# Altium schematic sheet Microcontroller.SchDoc(Microcontroller)
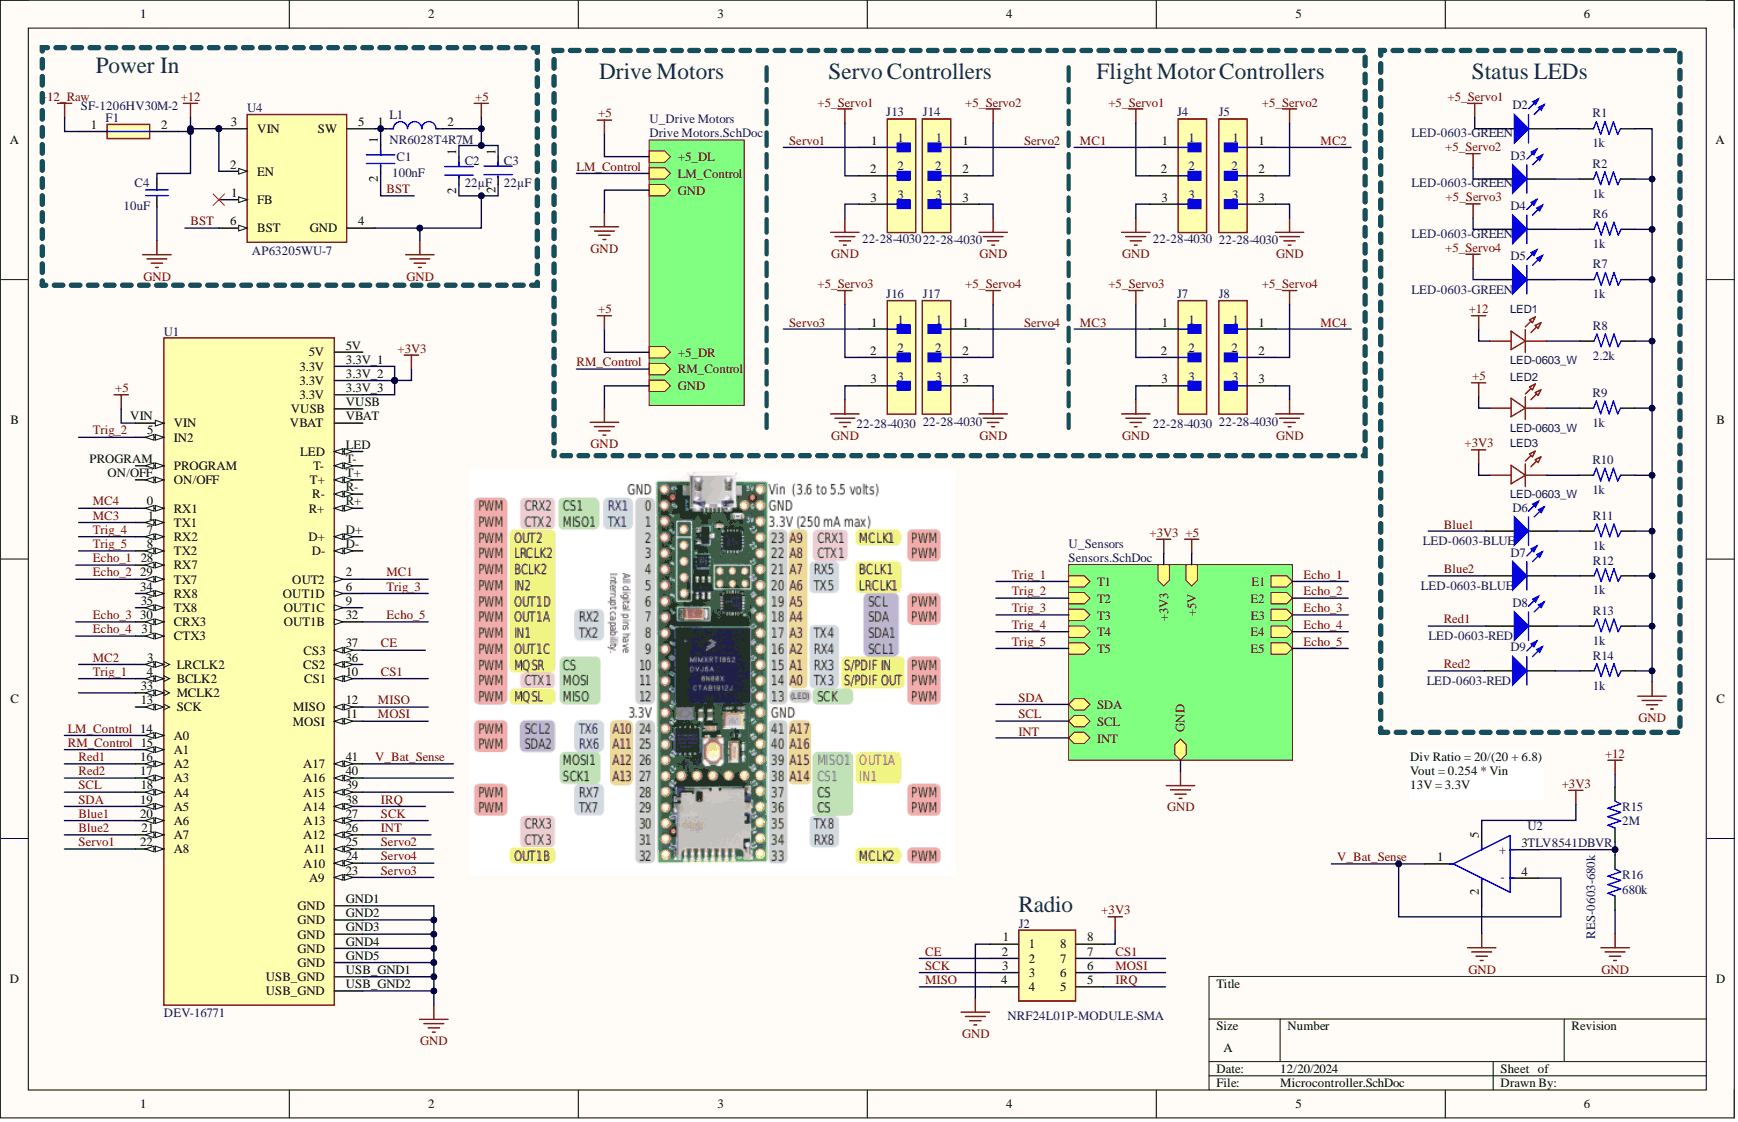
[diagram p0: Microcontroller.SchDoc]

## Parts
C1  Capacitance: 100nF
C2  Capacitance: 22µF
C3  Capacitance: 22µF
C4  value 10uF
D2  LED-0603-GREEN
D3  LED-0603-GREEN
D4  LED-0603-GREEN
D5  LED-0603-GREEN
D6  LED-0603-BLUE
D7  LED-0603-BLUE
D8  LED-0603-RED
D9  LED-0603-RED
F1  SF-1206HV30M-2
J2  NRF24L01P-MODULE-SMA
J4  22-28-4030
J5  22-28-4030
J7  22-28-4030
J8  22-28-4030
J13  22-28-4030
J14  22-28-4030
J16  22-28-4030
J17  22-28-4030
L1  NR6028T4R7M
LED1  LED-0603_W
LED2  LED-0603_W
LED3  LED-0603_W
R1  value 1k  (hidden comment: RES-0603-1k)
R2  value 1k  (hidden comment: RES-0603-1k)
R6  value 1k  (hidden comment: RES-0603-1k)
R7  value 1k  (hidden comment: RES-0603-1k)
R8  value 2.2k
R9  value 1k  (hidden comment: RES-0603-1k)
R10  value 1k  (hidden comment: RES-0603-1k)
R11  value 1k  (hidden comment: RES-0603-1k)
R12  value 1k  (hidden comment: RES-0603-1k)
R13  value 1k  (hidden comment: RES-0603-1k)
R14  value 1k  (hidden comment: RES-0603-1k)
R15  value 2M
R16  RES-0603-680k  value 680k
U1  DEV-16771
U2  TLV8541DBVR
U4  AP63205WU-7

## Nets
+3V3: J2.8 LED3.1 U1.3.3V_1(3.3V) U1.3.3V_2(3.3V) U1.3.3V_3(3.3V) U2.5
+5: C2.1 C3.1 L1.2 LED2.1 U1.VIN
+5_Servo1: D2.1 J4.2 J13.2
+5_Servo2: D3.1 J5.2 J14.2
+5_Servo3: D4.1 J7.2 J16.2
+5_Servo4: D5.1 J8.2 J17.2
+12: C4.1 F1.2 LED1.1 R15.2 U4.2(EN) U4.3(VIN)
+12_Raw: F1.1
GND: C2.2 C3.2 C4.2 J2.1 J4.3 J5.3 J7.3 J8.3 J13.3 J14.3 J16.3 J17.3 R1.2 R2.2 R6.2 R7.2 R8.2 R9.2 R10.2 R11.2 R12.2 R13.2 R14.2 R16.1 U1.GND1(GND) U1.GND2(GND) U1.GND3(GND) U1.GND4(GND) U1.GND5(GND) U1.USB_GND1(USB_GND) U1.USB_GND2(USB_GND) U2.2 U4.4(GND)
Blue1: D6.1 U1.20(A6)
Blue2: D7.1 U1.21(A7)
BST: C1.2 U4.6(BST)
CE: J2.2 U1.37(CS3)
CS1: J2.7 U1.10(CS1)
Echo_1: U1.28(RX7)
Echo_2: U1.29(TX7)
Echo_3: U1.30(CRX3)
Echo_4: U1.31(CTX3)
Echo_5: U1.32(OUT1B)
INT: U1.26(A12)
IRQ: J2.5 U1.38(A14)
LM_Control: U1.14(A0)
MC1: J4.1 U1.2(OUT2)
MC2: J5.1 U1.3(LRCLK2)
MC3: J7.1 U1.1(TX1)
MC4: J8.1 U1.0(RX1)
MISO: J2.4 U1.12(MISO)
MOSI: J2.6 U1.11(MOSI)
Red1: D8.1 U1.16(A2)
Red2: D9.1 U1.17(A3)
RM_Control: U1.15(A1)
SCK: J2.3 U1.27(A13)
SCL: U1.18(A4)
SDA: U1.19(A5)
Servo1: J13.1 U1.22(A8)
Servo2: J14.1 U1.25(A11)
Servo3: J16.1 U1.23(A9)
Servo4: J17.1 U1.24(A10)
Trig_1: U1.4(BCLK2)
Trig_2: U1.5(IN2)
Trig_3: U1.6(OUT1D)
Trig_4: U1.7(RX2)
Trig_5: U1.8(TX2)
V_Bat_Sense: U1.41(A17) U2.1 U2.4(-)
NetC1_1: C1.1 L1.1 U4.5(SW)
NetD2_2: D2.2 R1.1
NetD3_2: D3.2 R2.1
NetD4_2: D4.2 R6.1
NetD5_2: D5.2 R7.1
NetD6_2: D6.2 R11.1
NetD7_2: D7.2 R12.1
NetD8_2: D8.2 R13.1
NetD9_2: D9.2 R14.1
NetLED1_2: LED1.2 R8.1
NetLED2_2: LED2.2 R9.1
NetLED3_2: LED3.2 R10.1
NetR15_1: R15.1 R16.2 U2.3(+)
NetU1_5V: U1.5V
NetU1_9: U1.9(OUT1C)
NetU1_13: U1.13(SCK)
NetU1_33: U1.33(MCLK2)
NetU1_34: U1.34(RX8)
NetU1_35: U1.35(TX8)
NetU1_36: U1.36(CS2)
NetU1_39: U1.39(A15)
NetU1_40: U1.40(A16)
NetU1_D+: U1.D+
NetU1_D-: U1.D-
NetU1_LED: U1.LED
NetU1_ON/OFF: U1.ON/OFF
NetU1_PROGRAM: U1.PROGRAM
NetU1_R+: U1.R+
NetU1_R-: U1.R-
NetU1_T+: U1.T+
NetU1_T-: U1.T-
NetU1_VBAT: U1.VBAT
NetU1_VUSB: U1.VUSB
NetU4_1: U4.1(FB)

## Library data of the parts
C1 = CMP-292-000024-1: General Purpose Ceramic Capacitor, 0603, 100nF, 10%, X7R, 15%, 50V; Manufacturer: KEMET; Manufacturer Part Number: C0603C104K5RACTU; Dissipation Factor: 2.5%; Case Code (Metric): 1608; Component Kind: Standard; Component Type: Standard; Contact Plating: Tin; Depth: 0.81mm; Design Item ID: CMP-1035-02592-4; Dielectric: X7R; Dielectric Material: Ceramic; Height: 0.9mm; Lead Free: Lead Free; Length: 1.6mm; Max Operating Temperature: 125°C; Min Operating Temperature: -55°C; Mount: Surface Mount; Number of Pins: 2; Package Quantity: 1; Packaging: Tape and Reel; Pin Count: 2; Radiation Hardening: No; REACH SVHC: No SVHC; Source: PV Buck v2.SCHLIB; Termination: SMD/SMT; Thickness: 0.035inch; Tolerance: 10%; Voltage: 50V; Width: 0.81mm; Library Name: Formula Electric at Berkeley
C2 C3 = CMP-292-000025-1: Multilayer Ceramic Capacitors 22µF ±20% 35V X5R SMD 0805; Manufacturer: TDK; Manufacturer Part Number: C2012X5R1V226M125AC; Case Code (Metric): 2012; Component Kind: Standard; Component Type: Standard; Design Item ID: CMP-2000-06038-3; Dielectric: X5R; Dielectric Material: Ceramic; Height: 1.25mm; Lead Free: Lead Free; Length: 0.079inch; Max Operating Temperature: 85°C; Min Operating Temperature: -55°C; Mount: Surface Mount; Package Quantity: 2000; Packaging: Tape and Reel; Pin Count: 2; REACH SVHC: No SVHC; RoHS Compliant: Yes; Series: C; Source: PV Buck v2.SCHLIB; Termination: SMD/SMT; Thickness: 0.057inch; Tolerance: 20%; Weight: 0.000194oz; Width: 0.049inch; Library Name: Formula Electric at Berkeley
C4 = CMP-001-00003-3: 0603 Footprint Capacitor, 10uF; MP: CAP-0603-10u-25V; Availability: Unavailable; Component Kind: Standard; Design Item ID: CAP-0603-10u-25V; Digi-Key Part Number: 1276-1869-1-ND; JLCPCB Part Number: CL10A106MA8NRNC; Package: None; Price: None; Source: CAP0603.SchLib; Library Name: Formula Electric at Berkeley
D2-D5 = CMP-004-00001-4: Green 0603 SMD LED; Manufacturer Product Number: LTST-C190KGKT; Component Kind: Standard; Component Type: Standard; Design Item ID: LED-0603; Digi-Key Part Number: 160-1435-1-ND; JLCPCB Part Number: C125094; JLCPCB Part Type: Extended; Mouser Part Number: 859-LTST-C190KGKT; Pin Count: 2; Source: LED0603.SchLib; Library Name: Formula Electric at Berkeley
D6 D7 = CMP-004-00002-3: Blue 0603 SMD LED; Manufacturer Product Number: LTST-C191TBKT; Component Kind: Standard; Component Type: Standard; Design Item ID: LED-0603; Digi-Key Part Number: 160-1647-1-ND; JLCPCB Part Number: C99290; JLCPCB Part Type: Extended; Mouser Part Number: 859-LTST-C191TBKT; Pin Count: 2; Source: LED0603.SchLib; Library Name: Formula Electric at Berkeley
D8 D9 = CMP-004-00000-4: Red 0603 SMD LED; Manufacturer Product Number: LTST-C190KRKT; Component Kind: Standard; Component Type: Standard; Design Item ID: LED-0603; Digi-Key Part Number: 160-1436-1-ND; JLCPCB Part Number: C125099; JLCPCB Part Type: Extended; Mouser Part Number: 859-LTST-C190KRKT; Pin Count: 2; Source: LED0603.SchLib; Library Name: Formula Electric at Berkeley
F1 = CMP-265-000002-1: Surface Mount Fuses 30A Single blow fuse 1206 SinglFuse; Manufacturer_Name: Bourns; Arrow Part Number: SF-1206HV30M-2; Arrow Price/Stock: https://www.arrow.com/en/products/sf-1206hv30m-2/bourns?region=nac; Component Kind: Standard; Datasheet Link: https://componentsearchengine.com/Datasheets/1/SF-1206HV30M-2.pdf; Design Item ID: SF-1206HV30M-2; Height: 1.5mm; Manufacturer_Part_Number: SF-1206HV30M-2; Mouser Part Number: 652-SF-1206HV30M-2; Mouser Price/Stock: https://www.mouser.co.uk/ProductDetail/Bourns/SF-1206HV30M-2?qs=gTYE2QTfZfQ0x9wKqSFQQQ%3D%3D; Source: SamacSys.SchLib; Library Name: Formula Electric at Berkeley
J2 = NRF24L01P-MODULE-SMA: Connector; Manufacturer_Name: Nordic Semiconductor; Arrow Part Number: NRF24L01P-MODULE-SMA; Arrow Price/Stock: https://www.arrow.com/en/products/nrf24l01p-module-sma/nordic-semiconductor?region=nac; Component Kind: Standard; Datasheet Link: https://infocenter.nordicsemi.com/pdf/nRF24L01P_PS_v1.0.pdf; Height: 4mm; Manufacturer_Part_Number: NRF24L01P-MODULE-SMA; Mouser Part Number: 949-NRF24L01P-MODSMA; Mouser Price/Stock: https://www.mouser.co.uk/ProductDetail/Nordic-Semiconductor/nRF24L01P-MODULE-SMA?qs=yd0qOhnSiDftbZo%2FB8rkYg%3D%3D
J4 J5 J7 J8 J13 J14 J16 J17 = CMP-04776-000098-1: CONN HEADER VERT 3POS 2.54MM; Manufacturer: Molex; Conn Gender: Male; Conn Orientation: Vertical; Current Rating: 4A; Number Of Contacts: 3; Voltage Rating: 500V; Automotive: No; Category: Conn; Component Kind: Standard; ComponentLink2Description: Datasheet; ComponentLink2URL: https://www.molex.com/webdocs/datasheets/pdf/en-us/0022284030_PCB_HEADERS.pdf; Contact Material: Gold,Nickel; Device Class L1: Connectors; Device Class L2: Headers and Wire Housings; Device Class L3: unset; Digikey Description: CONN HEADER VERT 3POS 2.54MM; Footprint Url: https://www.molex.com/pdm_docs/sd/022284030_sd.pdf; Height: 8.88mm; Lead Free: Yes; Library Name: Altium Content Vault; Manufacturer Part Number: 22-28-4030; Mouser Description: Headers & Wire Housings 3P VERT HEADER Sn; Number Of Rows: 1; Octopart: https://octopart.com/0022284030-molex-10476094; Package: 22-28-4030; Pitch: 2.54mm; RoHS: TRUE; Temperature: -40°C to +80°C; Temperature Range High: +80°C; Temperature Range Low: -40°C
L1 = CMP-14484-000037-2: SMD Power Inductors 4.7uH ±20% 2.7A 40.3mΩ; Core Material: Ferrite; Current Rating: 3A; DC Resistance (DCR): 40.3mR; Self Resonant Frequency: 39MHz; Shielding: Shielded; Test Frequency: 100kHz; Case/Package: 2424; Component Kind: Standard; Depth: 6.2mm; Halogen Free: Halogen Free; Height: 2.8mm; Height - Seated (Max): 0.11inch; Inductance: 4.7uH; Inductance Tolerance: 20%; Lead Free: Lead Free; Length: 0.236inch; Library Name: Altium Content Vault; Max DC Current: 3A; Max Operating Temperature: 120°C; Min Operating Temperature: -25°C; Mount: Surface Mount; Number of Pins: 2; Package Quantity: 2000; Packaging: Tape and Reel; Radiation Hardening: No; Resistor Type: Wirewound; RMS Current (Irms): 3A; RoHS Compliant: Yes; Saturation Current: 2.7A; Series: NR; Termination: SMD/SMT; Tolerance: 20%; Width: 0.236inch
LED1-LED3 = CMP-284-000000-3: White 0603 LED Indication - Discrete ROHS; BOM_Manufacturer: KENTO; BOM_Manufacturer Part: LED White; Manufacturer: Hubei KENTO Elec; Manufacturer Part Number: KT-0603W; BOM_Supplier: LCSC; BOM_Supplier Part: C2290; Component Kind: Standard; Datasheet URL: https://www.lcsc.com/datasheet/lcsc_datasheet_2305091500_Hubei-KENTO-Elec-KT-0603W_C2290.pdf; Design Item ID: LED-0603_W; JLCPCB Part Number: C2290; link: https://atta.szlcsc.com//upload/public/pdf/source/20160507/1462613613821.pdf; Source: All_JLC_components.SCHLIB; spicePre: L; spiceSymbolName: LED-0603_W; Library Name: Formula Electric at Berkeley
R1 R2 R6 R7 R9-R14 = CMP-009-00012-2: 0603 1kOhm Resistor; Manufacturer Product Number: RC1608F102CS; Tolerance: 1%; Voltage Rating: 50V; Component Kind: Standard; Digi-Key Part Number: 1276-3484-1-ND; JLCPCB Part Number: C21190; Source: RES0603.SchLib; Library Name: Formula Electric at Berkeley
R8 = CMP-397-000182-1: 0603 2.2kOhm Resistor; Manufacturer Product Number: RC0603FR-072K2L; Power: 1/10; Tolerance: 1%; Component Kind: Standard; Digi-Key Part Number: 311-2.20KHRCT-ND; Digi-Key_Purchase_URL: https://www.digikey.com/en/products/detail/yageo/RC0603FR-072K2L/727016; JLCPCB Part Type: Basic/Extended; Package: 0603; Source: RES0603.SchLib; Library Name: Formula Electric at Berkeley
R15 = CMP-009-00026-2: 0603 1 Ohm Resistor; Manufacturer Product Number: RC0603FR-071RP; Tolerance: 1%; Case/Package: 0603; Component Kind: Standard; Digi-Key Part Number: YAG1295CT-ND; JLCPCB Part Number: C22936; Mouser Part Number: 603-RC0603FR-071RP; Library Name: Formula Electric at Berkeley
R16 = CMP-009-00031-2: 680kOhm 0603 Resistor; Manufacturer Product Number: RC0603FR-07680KL; MP: 3-2176326-0; Availability: Unavailable; Component Kind: Standard; Design Item ID: 3-2176326-0; Digi-Key Part Number: 311-680KHRCT-ND; JLCPCB Part Number: C25822; Mouser Part Number: 603-RC0603FR-07680KL; Package: None; Price: None; Source: RES0603.SchLib; Library Name: Formula Electric at Berkeley
U1 = CMP-661-000000-1: RT1062 Teensy 4.1 series ARM® Cortex®-M7 MPU Embedded Evaluation Board; MF: SparkFun Electronics; Check_prices: https://www.snapeda.com/parts/DEV-16771/SparkFun+Electronics/view-part/?ref=eda; Component Kind: Standard; Component Type: Standard; Design Item ID: DEV-16771; MP: DEV-16771; Package: None; Pin Count: 65; SnapEDA_Link: https://www.snapeda.com/parts/DEV-16771/SparkFun+Electronics/view-part/?ref=snap; Source: DEV-16771.SchLib; Library Name: Formula Electric at Berkeley
U2 = CMP-399-000028-1: Operational Amplifier, 1 Func, 3100uV Offset-Max, PDSO5, opamp; Channels: 1; Common-mode Reject Ratio-Nom: 80dB; Input Offset Voltage-Max: 3.1mV; Nominal Supply Voltage: 1.8V; Package Body Material: Plastic; Slew Rate: 3500V/µs; Supply Voltage Limit-Max: 4V; Unity Gain BW-Nom: 8kHz; Amplifier Type: OPERATIONAL AMPLIFIER; Case/Package: LSSOP; Component Kind: Standard; Height - Seated (Max): 1.45mm; JESD-30 Code: R-PDSO-G5; JESD-609 Code: e4; Length: 2.9mm; Max Operating Temperature: 125°C; Min Operating Temperature: -40°C; Moisture Sensitivity Level: 1; Package Shape: Rectangular; Package Style: SMALL OUTLINE, LOW PROFILE, SHRINK PITCHMeter; Peak Reflow Temperature (Cel): 260°C; Pins: 5; Reach SVHC Compliant: Yes; RoHS Compliant: Yes; Surface Mount: Yes; Temperature Grade: AUTOMOTIVE; Terminal Finish: Nickel; Terminal Form: Gull Wing; Terminal Pitch: 0.95mm; Terminal Position: DUAL; Width: 1.6mm; Library Name: Formula Electric at Berkeley
U4 = CMP-12757-000001-1: IC REG BUCK 5V 2A TSOT26; Manufacturer: Diodes Inc.; Max Duty Cycle: 100%; Max Junction Temp: +160°C; Max Supply Voltage: 32V; Min Supply Voltage: 3.8V; Nominal Supply Current: 22uA; Number Of Outputs: 1; Output Type: Fixed; Standoff Height: 0.01mm; Switching Frequency: 1100kHz; Ambient Temperature Range High: +85°C; Ambient Temperature Range Low: -40°C; Automotive: No; Category: IC; Component Kind: Standard; ComponentLink2Description: Datasheet; ComponentLink2URL: https://www.diodes.com/assets/Datasheets/AP63200-AP63201-AP63203-AP63205.pdf; Device Class L1: Integrated Circuits (ICs); Device Class L2: Power Management ICs; Device Class L3: Voltage Regulators - Switching; Digikey Description: IC REG BUCK 5V 2A TSOT26; Footprint Url: https://www.diodes.com/assets/Package-Files/TSOT26.pdf; Height: 1mm; Lead Free: Yes; Library Name: Altium Content Vault; Manufacturer Part Number: AP63205WU-7; Octopart: https://octopart.com/ap63205wu-7-diodes+inc.-98099551; Output Current: 2A; Output Voltage: 5V; Package: TSOT26; RoHS: TRUE; Switching Topology: Buck; Temperature: -40°C to +85°C; Temperature Range High: +85°C; Temperature Range Low: -40°C
